# Altium schematic sheet Inverter.SchDoc
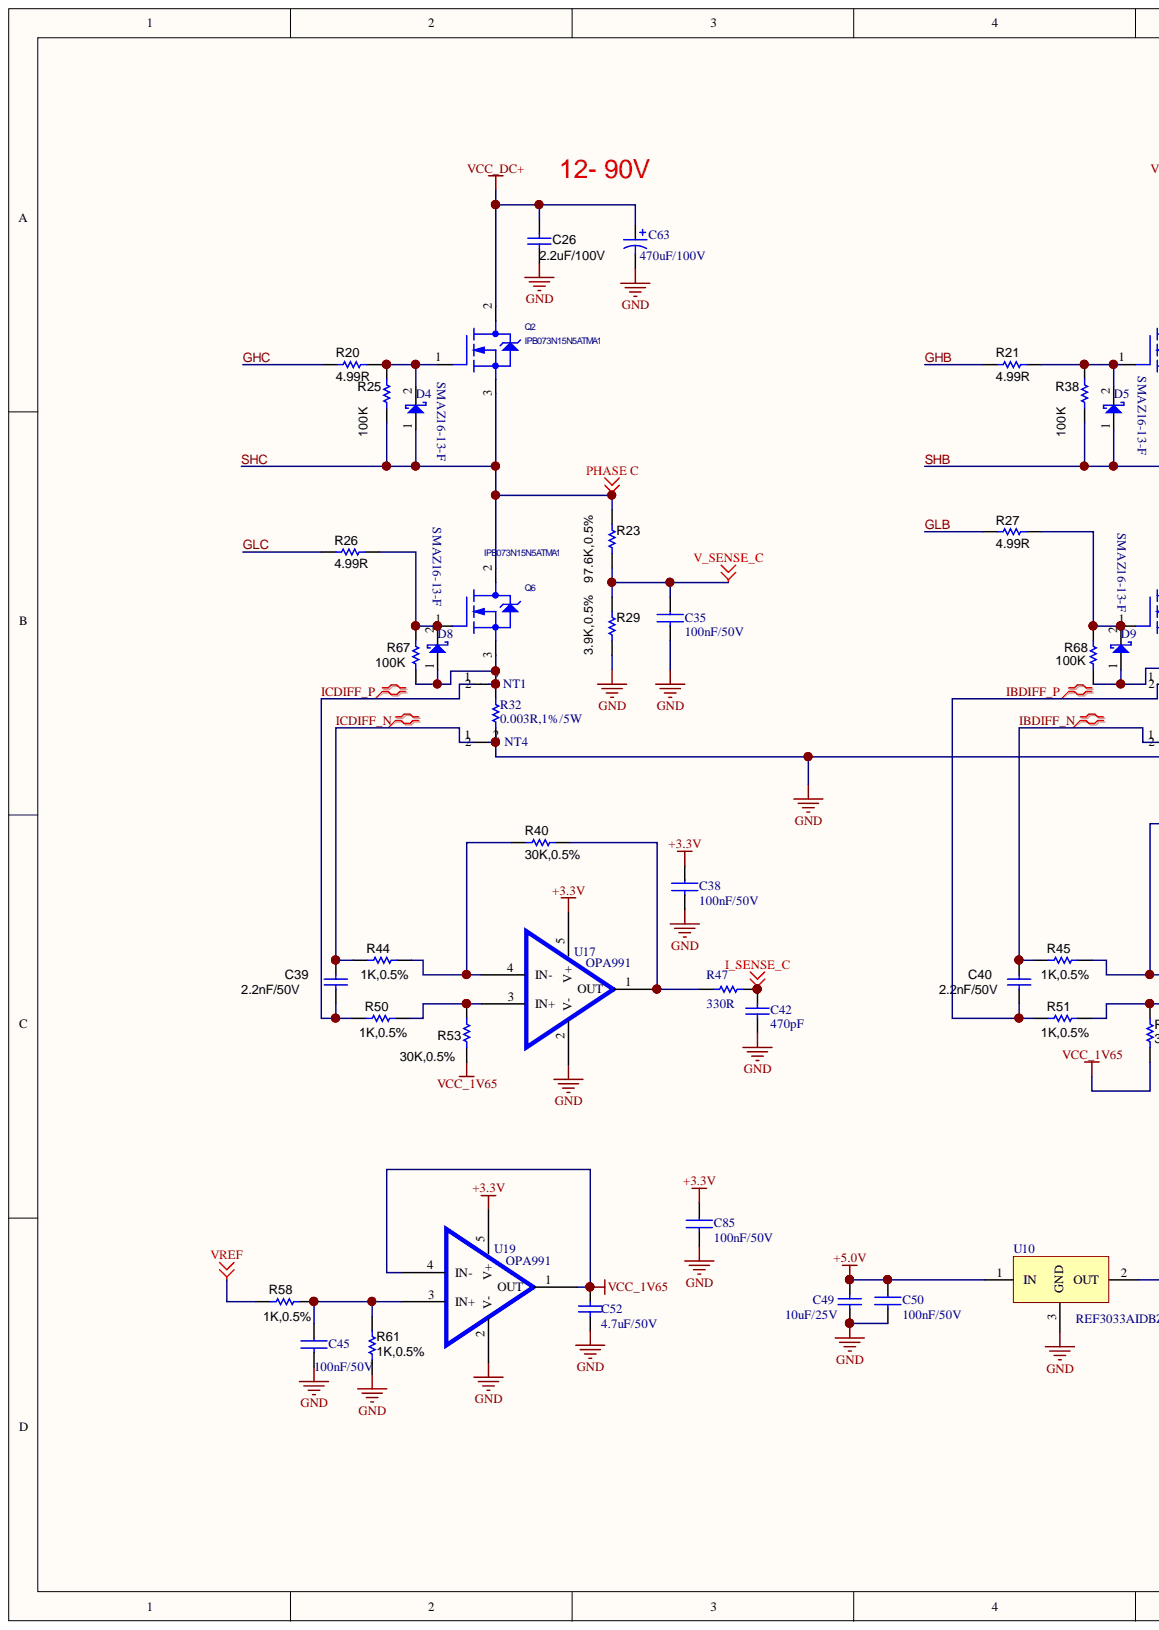
[diagram p0: Inverter.SchDoc, left]
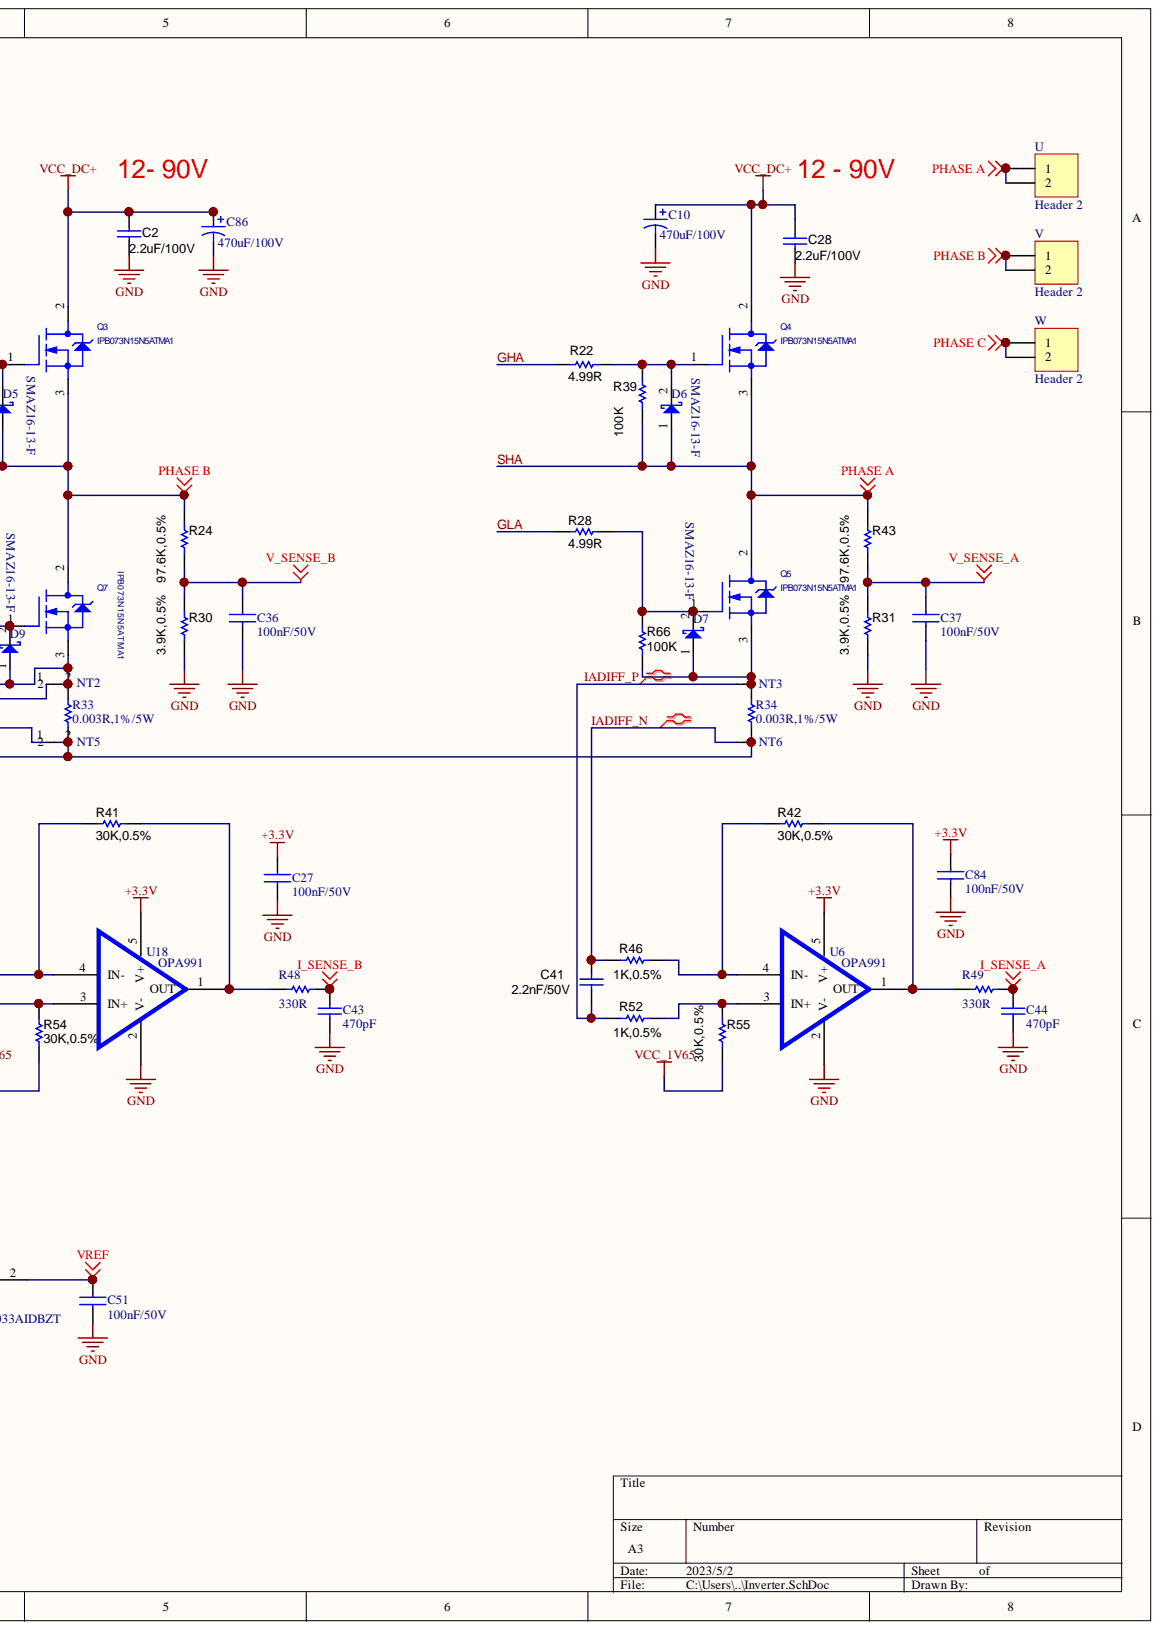
[diagram p1: Inverter.SchDoc, right]

## Parts
C2  2.2uF/100V  [1210]
C10  470uF/100V  [Can_5.0_12.5]
C26  2.2uF/100V  [1210]
C27  100nF/50V  [0603]
C28  2.2uF/100V  [1210]
C35  100nF/50V  [0603]
C36  100nF/50V  [0603]
C37  100nF/50V  [0603]
C38  100nF/50V  [0603]
C39  2.2nF/50V  [0603]
C40  2.2nF/50V  [0603]
C41  2.2nF/50V  [0603]
C42  470pF  [0603]
C43  470pF  [0603]
C44  470pF  [0603]
C45  100nF/50V  [0603]
C49  10uF/25V  [1206]
C50  100nF/50V  [0603]
C51  100nF/50V  [0603]
C52  4.7uF/50V  [1206]
C63  470uF/100V  [Can_5.0_12.5]
C84  100nF/50V  [0603]
C85  100nF/50V  [0603]
C86  470uF/100V  [Can_5.0_12.5]
D4  SMAZ16-13-F  [Zener_SMA]
D5  SMAZ16-13-F  [Zener_SMA]
D6  SMAZ16-13-F  [Zener_SMA]
D7  SMAZ16-13-F  [Zener_SMA]
D8  SMAZ16-13-F  [Zener_SMA]
D9  SMAZ16-13-F  [Zener_SMA]
NT1  NT  [diff_net_tie]
NT2  NT  [diff_net_tie]
NT3  NT  [diff_net_tie]
NT4  NT  [diff_net_tie]
NT5  NT  [diff_net_tie]
NT6  NT  [diff_net_tie]
Q2  IPB073N15N5ATMA1  [TO-263AB]
Q3  IPB073N15N5ATMA1  [TO-263AB]
Q4  IPB073N15N5ATMA1  [TO-263AB]
Q5  IPB073N15N5ATMA1  [TO-263AB]
Q6  IPB073N15N5ATMA1  [TO-263AB]
Q7  IPB073N15N5ATMA1  [TO-263AB]
R20  4.99R  [0603]
R21  4.99R  [0603]
R22  4.99R  [0603]
R23  97.6K,0.5%  [0603]
R24  97.6K,0.5%  [0603]
R25  100K  [0603]
R26  4.99R  [0603]
R27  4.99R  [0603]
R28  4.99R  [0603]
R29  3.9K,0.5%  [0603]
R30  3.9K,0.5%  [0603]
R31  3.9K,0.5%  [0603]
R32  0.003R,1%/5W  [4527]
R33  0.003R,1%/5W  [4527]
R34  0.003R,1%/5W  [4527]
R38  100K  [0603]
R39  100K  [0603]
R40  30K,0.5%  [0603]
R41  30K,0.5%  [0603]
R42  30K,0.5%  [0603]
R43  97.6K,0.5%  [0603]
R44  1K,0.5%  [0603]
R45  1K,0.5%  [0603]
R46  1K,0.5%  [0603]
R47  330R  [0603]
R48  330R  [0603]
R49  330R  [0603]
R50  1K,0.5%  [0603]
R51  1K,0.5%  [0603]
R52  1K,0.5%  [0603]
R53  30K,0.5%  [0603]
R54  30K,0.5%  [0603]
R55  30K,0.5%  [0603]
R58  1K,0.5%  [0603]
R61  1K,0.5%  [0603]
R66  100K  [0603]
R67  100K  [0603]
R68  100K  [0603]
U  Header 2  [KF8500-8.5MM-2P]
U6  OPA991  [SOT-235]
U10  REF3033AIDBZT  [SOT-233]
U17  OPA991  [SOT-235]
U18  OPA991  [SOT-235]
U19  OPA991  [SOT-235]
V  Header 2  [KF8500-8.5MM-2P]
W  Header 2  [KF8500-8.5MM-2P]

## Nets
+3.3V: C27.1 C38.1 C84.1 C85.1 U6.5(V+) U17.5(V+) U18.5(V+) U19.5(V+)
+5.0V: C49.2 C50.1 U10.1
GND: C2.2 C10.2 C26.2 C27.2 C28.2 C35.2 C36.2 C37.2 C38.2 C42.2 C43.2 C44.2 C45.2 C49.1 C50.2 C51.2 C52.1 C63.2 C84.2 C85.2 C86.2 NT4.2 NT5.2 NT6.2 R29.1 R30.1 R31.1 R32.2 R33.2 R34.2 R61.1 U6.2(V-) U10.3 U17.2(V-) U18.2(V-) U19.2(V-)
I_SENSE_A: C44.1 R49.1
I_SENSE_B: C43.1 R48.1
I_SENSE_C: C42.1 R47.1
V_SENSE_A: C37.1 R31.2 R43.1
V_SENSE_B: C36.1 R24.1 R30.2
V_SENSE_C: C35.1 R23.1 R29.2
VCC_1V65: C52.2 R53.2 R54.2 R55.1 U19.1(OUT) U19.4(IN-)
VCC_DC+: C2.1 C10.1 C26.1 C28.1 C63.1 C86.1 Q2.2 Q3.2 Q4.2
VREF: C51.1 R58.1 U10.2
GHA: R22.1
GHB: R21.1
GHC: R20.1
GLA: R28.1
GLB: R27.1
GLC: R26.1
IADIFF_N: C41.1 NT6.1 R46.1
IADIFF_P: C41.2 NT3.1 R52.1
IBDIFF_N: C40.1 NT5.1 R45.1
IBDIFF_P: C40.2 NT2.1 R51.1
ICDIFF_N: C39.1 NT4.1 R44.1
ICDIFF_P: C39.2 NT1.1 R50.1
SHA: D6.1 Q4.3 Q5.2 R39.1 R43.2 U.1 U.2
SHB: D5.1 Q3.3 Q7.2 R24.2 R38.1 V.1 V.2
SHC: D4.1 Q2.3 Q6.2 R23.2 R25.1 W.1 W.2
NetC45_1: C45.1 R58.2 R61.2 U19.3(IN+)
NetD4_2: D4.2 Q2.1 R20.2 R25.2
NetD5_2: D5.2 Q3.1 R21.2 R38.2
NetD6_2: D6.2 Q4.1 R22.2 R39.2
NetD7_1: D7.1 NT3.2 Q5.3 R34.1 R66.2
NetD7_2: D7.2 Q5.1 R28.2 R66.1
NetD8_1: D8.1 NT1.2 Q6.3 R32.1 R67.1
NetD8_2: D8.2 Q6.1 R26.2 R67.2
NetD9_1: D9.1 NT2.2 Q7.3 R33.1 R68.1
NetD9_2: D9.2 Q7.1 R27.2 R68.2
NetR40_1: R40.1 R47.2 U17.1(OUT)
NetR40_2: R40.2 R44.2 U17.4(IN-)
NetR41_1: R41.1 R48.2 U18.1(OUT)
NetR41_2: R41.2 R45.2 U18.4(IN-)
NetR42_1: R42.1 R49.2 U6.1(OUT)
NetR42_2: R42.2 R46.2 U6.4(IN-)
NetR50_2: R50.2 R53.1 U17.3(IN+)
NetR51_2: R51.2 R54.1 U18.3(IN+)
NetR52_2: R52.2 R55.2 U6.3(IN+)

## Library data of the parts
C2 C26 C28 = GRM32ER71K475KE14L: Manufacturer: MuRata; AEC Category: Q200; AEC Qualified: N; Cap_F: 0.0000047; CompType: Capacitor; SizeArea_mm2: 8; SizeAreaWB_mm2: 14.7; SizeX_mm: 3.2; SizeY_mm: 2.5; SizeZ_mm: 2.7; TaMax_C: 125; TaMin_C: -55; TempCo: X7R; Tolerance_%: 10; U/M: EA; Value: 4.7uF; Value1: Unknown; Value2: 80V; ValueDisplayed: 4.7湩; VDC_V: 80; AEC Grade: N/A; Cap_Case: 1210; Component Kind: Standard; ComponentLink1Description: Datasheet link; ComponentLink1URL: http://psearch.en.murata.com/capacitor/product/GRM32ER71K475KE14%23.pdf; LatestRevisionDate: 2016-12-05; Library Name: Capacitor_Ceramic.IntLib; MountType: SMT; PackageReference: 1210; PartNumber: GRM32ER71K475KE14L; Polarized: N; Published: 2008-06-19; RoHS: Y; Signal Integrity: Cap; Simulation: Cap; Supplier 1: Digi-Key; Supplier 2: Mouser; Supplier Part Number 1: 490-9972-1-ND; Supplier Part Number 2: 81-GRM32ER71K475KE4L; SymbolVersion: 2008-07-09; Technology: Ceramic; Publisher: Texas Instruments
C10 C63 C86 = Cap Pol1: EKYB101ELL471MK40S; Value: 10uF; Component Kind: Standard; LatestRevisionDate: 17-Jul-2002; LatestRevisionNote: Re-released for DXP Platform.; PackageReference: RB7.6-15; Published: 8-Jun-2000; Publisher: Altium Limited
C27 C35-C38 C45 C50 C51 C84 C85 = Component-CAP: User Parameter 1: foot; Component Kind: Standard; Library Name: AltiumDesigner_Lib-tony.SchLib
C39-C41 = GRM188R71C102KA01D: Manufacturer: MuRata; Cap_F: 0.000000001; CompType: Capacitor; Polarized: N; TaMax_C: 125; TaMin_C: -55; TempCo: X7R; Tolerance_%: 10; U/M: EA; Value: 1000pF; Value1: Unknown; Value2: 16V; VDC_V: 16; Cap_Case: 0603; Component Kind: Standard; ComponentLink1Description: Datasheet link; ComponentLink1URL: http://search.murata.co.jp/Ceramy/PnsearchViewAction.do?HnOFG=ON&HnNFG=ON&SEL_CNT=50&sLang=en&sKey=GRM188R71C102KA01D&x=9&y=9&search=startwith; LatestRevisionDate: 2014-07-08; Library Name: Capacitor_Ceramic.IntLib; MountType: SMT; PackageReference: 0603; PartNumber: GRM188R71C102KA01D; Published: 2008-06-19; RoHS: Y; Signal Integrity: Cap; Simulation: Cap; Supplier 1: Digi-Key; Supplier 2: Mouser; Supplier Part Number 1: GRM188R71C102KA01D-ND; Supplier Part Number 2: 81-GRM188R71C102KA1D; SymbolVersion: 2008-07-09; Technology: Ceramic; ValueDisplayed: 1000pF; Publisher: Texas Instruments
C42-C44 C49 C52 = C1206: Component Kind: Standard; Library Name: useForA104.IntLib
D4-D9 = Diode-Schottky: Component Kind: Standard; Library Name: AD_Lib.SchLib
NT1-NT6 = diffpair_net_tie: Component Kind: Net Tie; Library Name: AltiumDesigner_Lib-syc.SchLib
Q2-Q7 = MOSFET_N_2: Part Number: D3-0080040; Component Height: 4.826MM; Component Kind: Standard
R20 R22 R26 R28 = CRCW060310R0FKEA: Manufacturer: Vishay-Dale; CompType: Resistor; EIA_Code: E96; Power_W: 0.1; Res_ohm: 10; TempCo: 100 ppm/C; Tolerance_%: 1; U/M: EA; Value: 10.0; Value1: 1%; Value2: 0.1W; Value_Code: 10R0; VDC_V: 75; Component Kind: Standard; ComponentLink1Description: Datasheet link; ComponentLink1URL: http://www.vishay.com/docs/20035/dcrcwe3.pdf; LatestRevisionDate: 2014-05-20; Library Name: Resistor_0603.IntLib; MountType: SMT; PackageReference: 0603; PartNumber: CRCW060310R0FKEA; Published: 2008-06-26; RoHS: Y; Signal Integrity: Resistor; Simulation: Resistor; Supplier 1: Digi-Key; Supplier 2: Mouser; Supplier 3: Farnell; Supplier Part Number 1: 541-10.0HCT-ND; Supplier Part Number 2: 71-CRCW0603-10-E3; Supplier Part Number 3: 1469751; SymbolVersion: 2009-05-29; Technology: Thick Film; Publisher: Texas Instruments
R21 R27 = CRCW060310R0FKEA: RES, 10.0 ohm, 1%, 0.1W, 0603; Manufacturer: Vishay-Dale; CompType: Resistor; EIA_Code: E96; Power_W: 0.1; Res_ohm: 10; TempCo: 100 ppm/C; Tolerance_%: 1; U/M: EA; Value: 10.0; Value_Code: 10R0; VDC_V: 75; Component Kind: Standard; ComponentLink1Description: Datasheet link; ComponentLink1URL: http://www.vishay.com/docs/20035/dcrcwe3.pdf; LatestRevisionDate: 2014-05-20; Library Name: Resistor_0603.IntLib; MountType: SMT; PackageReference: 0603; PartNumber: CRCW060310R0FKEA; Published: 2008-06-26; RoHS: Y; Signal Integrity: Resistor; Simulation: Resistor; Supplier 1: Digi-Key; Supplier 2: Mouser; Supplier 3: Farnell; Supplier Part Number 1: 541-10.0HCT-ND; Supplier Part Number 2: 71-CRCW0603-10-E3; Supplier Part Number 3: 1469751; SymbolVersion: 2009-05-29; Technology: Thick Film; Value1: 1%; Value2: 0.1W; Publisher: Texas Instruments
R23-R25 R38 R39 R43 R66-R68 = RG1608P-8662-B-T5: Manufacturer: Susumu Co Ltd; CompType: Resistor; EIA_Code: E192; Power_W: 0.1; Res_ohm: 86600; TempCo: 25 ppm/C; U/M: EA; Value: 86.6k; Value1: 0.1%; Value2: 0.1W; Value_Code: 86k6; VDC_V: 75; Component Kind: Standard; ComponentLink1Description: Datasheet link; ComponentLink1URL: http://www.susumu.co.jp/english/pdf/products-j01-01.pdf; LatestRevisionDate: 2014-05-20; Library Name: Resistor_0603.IntLib; MountType: SMT; PackageReference: 0603; PartNumber: RG1608P-8662-B-T5; Published: 2008-06-26; RoHS: Y; Signal Integrity: Resistor; Simulation: Resistor; Supplier 1: Digi-Key; Supplier 2: Mouser; Supplier Part Number 1: RG16P86.6KBCT-ND; Supplier Part Number 2: 754-RG1608P-8662-BT5; SymbolVersion: 2009-05-29; Technology: Metal Film; Tolerance_%: 0.1; Publisher: Texas Instruments
R29-R31 R40-R42 R53-R55 = RG1608P-4991-B-T5: Manufacturer: Susumu Co Ltd; CompType: Resistor; EIA_Code: E192; Power_W: 0.1; Res_ohm: 4990; TempCo: 25 ppm/C; U/M: EA; Value: 4.99k; Value1: 0.1%; Value2: 0.1W; Value_Code: 4k99; VDC_V: 75; Component Kind: Standard; ComponentLink1Description: Datasheet link; ComponentLink1URL: http://www.susumu.co.jp/english/pdf/products-j01-01.pdf; LatestRevisionDate: 2014-05-20; Library Name: Resistor_0603.IntLib; MountType: SMT; PackageReference: 0603; PartNumber: RG1608P-4991-B-T5; Published: 2008-06-26; RoHS: Y; Signal Integrity: Resistor; Simulation: Resistor; Supplier 1: Digi-Key; Supplier 2: Mouser; Supplier Part Number 1: RG16P4.99KBCT-ND; Supplier Part Number 2: 754-RG1608P-4991-BT5; SymbolVersion: 2009-05-29; Technology: Metal Film; Tolerance_%: 0.1; Publisher: Texas Instruments
R32-R34 = Res1: Value: 2.2K; Component Kind: Standard; LatestRevisionDate: 17-Jul-2002; LatestRevisionNote: Re-released for DXP Platform.; Library Name: Miscellaneous Devices.IntLib; PackageReference: AXIAL-0.3; Published: 8-Jun-2000; Signal Integrity: Res; Simulation: RESISTOR; Publisher: Altium Limited
R44-R46 R50-R52 R58 R61 = RC0603FR-071KL: Manufacturer: Yageo America; CompType: Resistor; EIA_Code: E96; Power_W: 0.1; Res_ohm: 1000; TempCo: 200 ppm/C; Tolerance_%: 1; U/M: EA; Value: 1.00k; Value1: 1%; Value2: 0.1W; Value_Code: 1k00; VDC_V: 150; Component Kind: Standard; ComponentLink1Description: Datasheet link; ComponentLink1URL: http://www.yageo.com/pdf/Pu-RC0603_51_PbFree_L_2.pdf; LatestRevisionDate: 2014-05-20; Library Name: Resistor_0603.IntLib; MountType: SMT; PackageReference: 0603; PartNumber: RC0603FR-071KL; Published: 2008-06-26; RoHS: Y; Signal Integrity: Resistor; Simulation: Resistor; Supplier 1: Digi-Key; Supplier 2: Mouser; Supplier 3: Farnell; Supplier Part Number 1: 311-1.00KHRCT-ND; Supplier Part Number 2: 603-RC0603FR-071KL; Supplier Part Number 3: 9238484; SymbolVersion: 2009-05-29; Technology: Thick Film; Publisher: Texas Instruments
R47-R49 = R1206: Component Kind: Standard; Library Name: useForA104.IntLib
U V W = Header 2: Header, 2-Pin; Component Kind: Standard; LatestRevisionDate: 17-Jul-2002; LatestRevisionNote: Re-released for DXP Platform.; Library Name: Miscellaneous Connectors.IntLib; Signal Integrity: Connector; Publisher: Altium Limited
U6 U17-U19 = TI-OPA991: Component Kind: Standard; Library Name: AltiumDesigner_Lib-syc.SchLib
U10 = TI-REF303x: Component Kind: Standard; Library Name: AltiumDesigner_Lib-syc.SchLib
